# Altium schematic sheet 04_POWER.SchDoc(04_POWER)
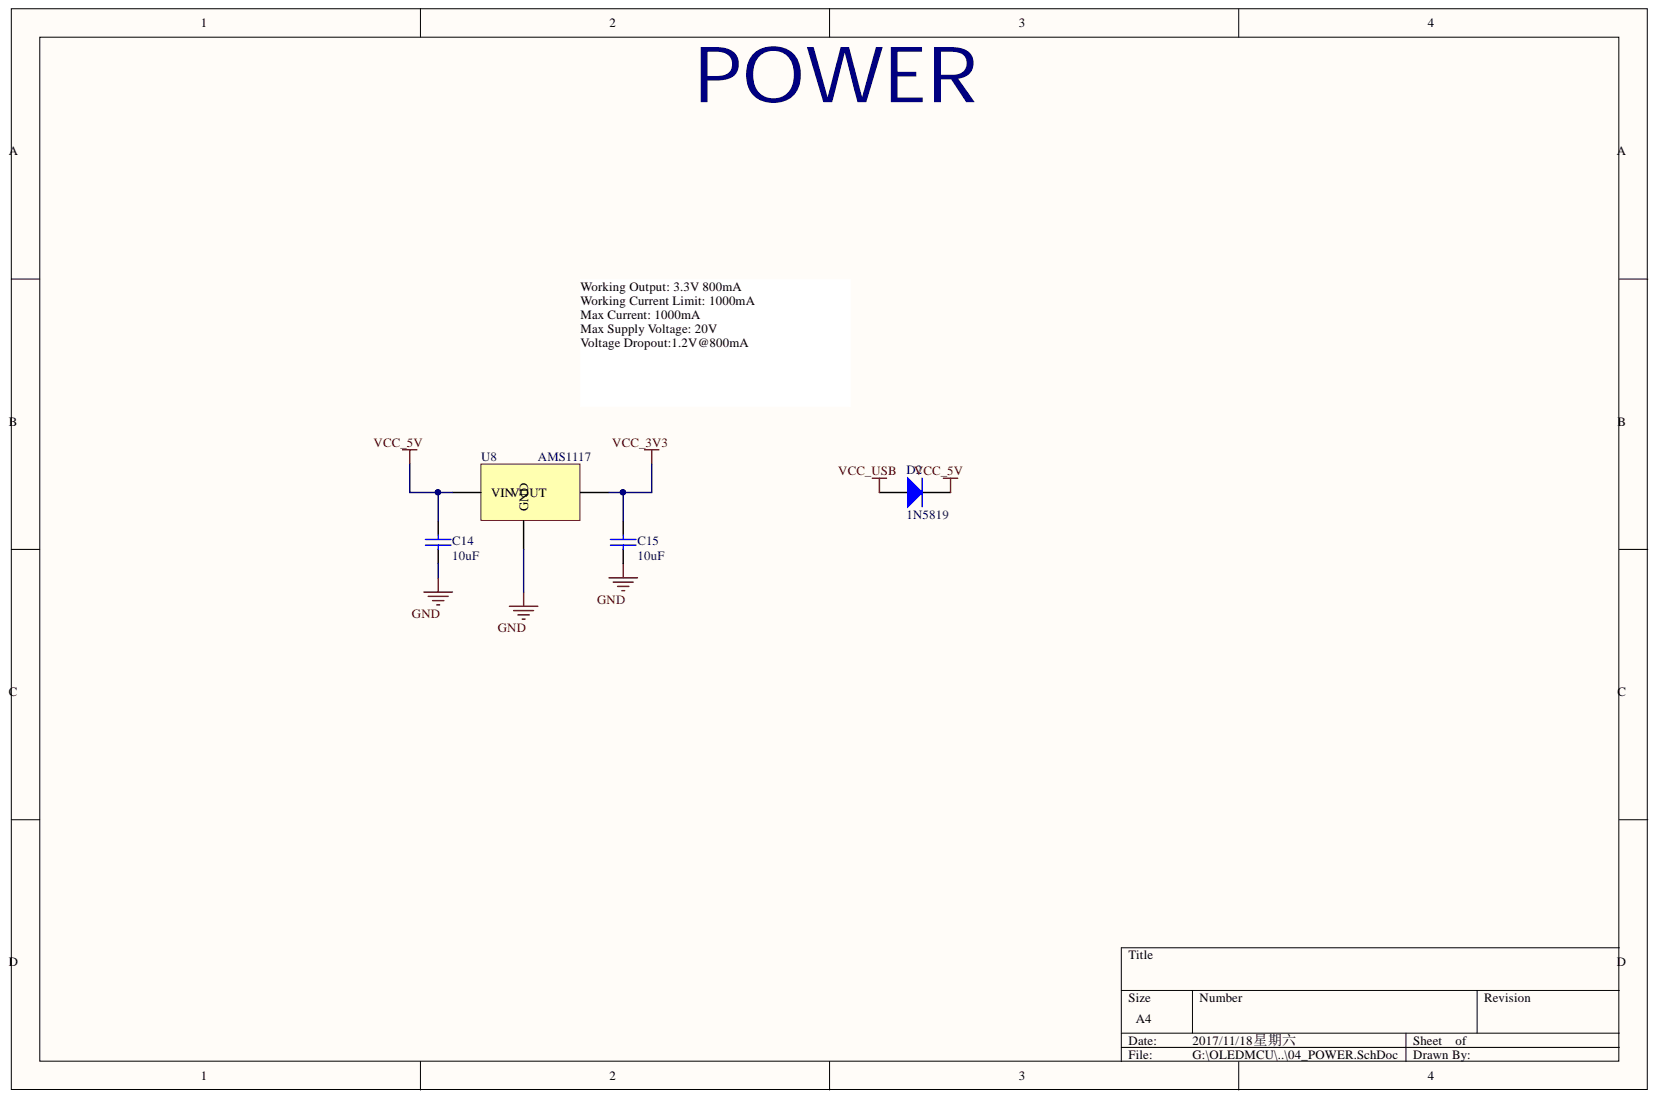
[diagram p0: 04_POWER.SchDoc]

## Parts
C14  10uF  [C0603]
C15  10uF  [C0603]
D2  1N5819  [1n4148]
U8  AMS1117  [AMS1117]

## Nets
GND: C14.2 C15.2 U8.1
VCC_3V3: C15.1 U8.2
VCC_5V: C14.1 D2.2 U8.3
VCC_USB: D2.1

## Library data of the parts
C14 C15 = C0603: 贴片电容; Component Kind: Standard; Library Name: Schlib_OLEDMCU.SchLib
D2 = 1N4148: 二极管; Component Kind: Standard; Library Name: Schlib_OLEDMCU.SchLib
U8 = AMS1117: 3.3V稳压芯片; Component Kind: Standard; Library Name: Schlib_OLEDMCU.SchLib
